# Altium schematic sheet Communication.SchDoc(Designator)
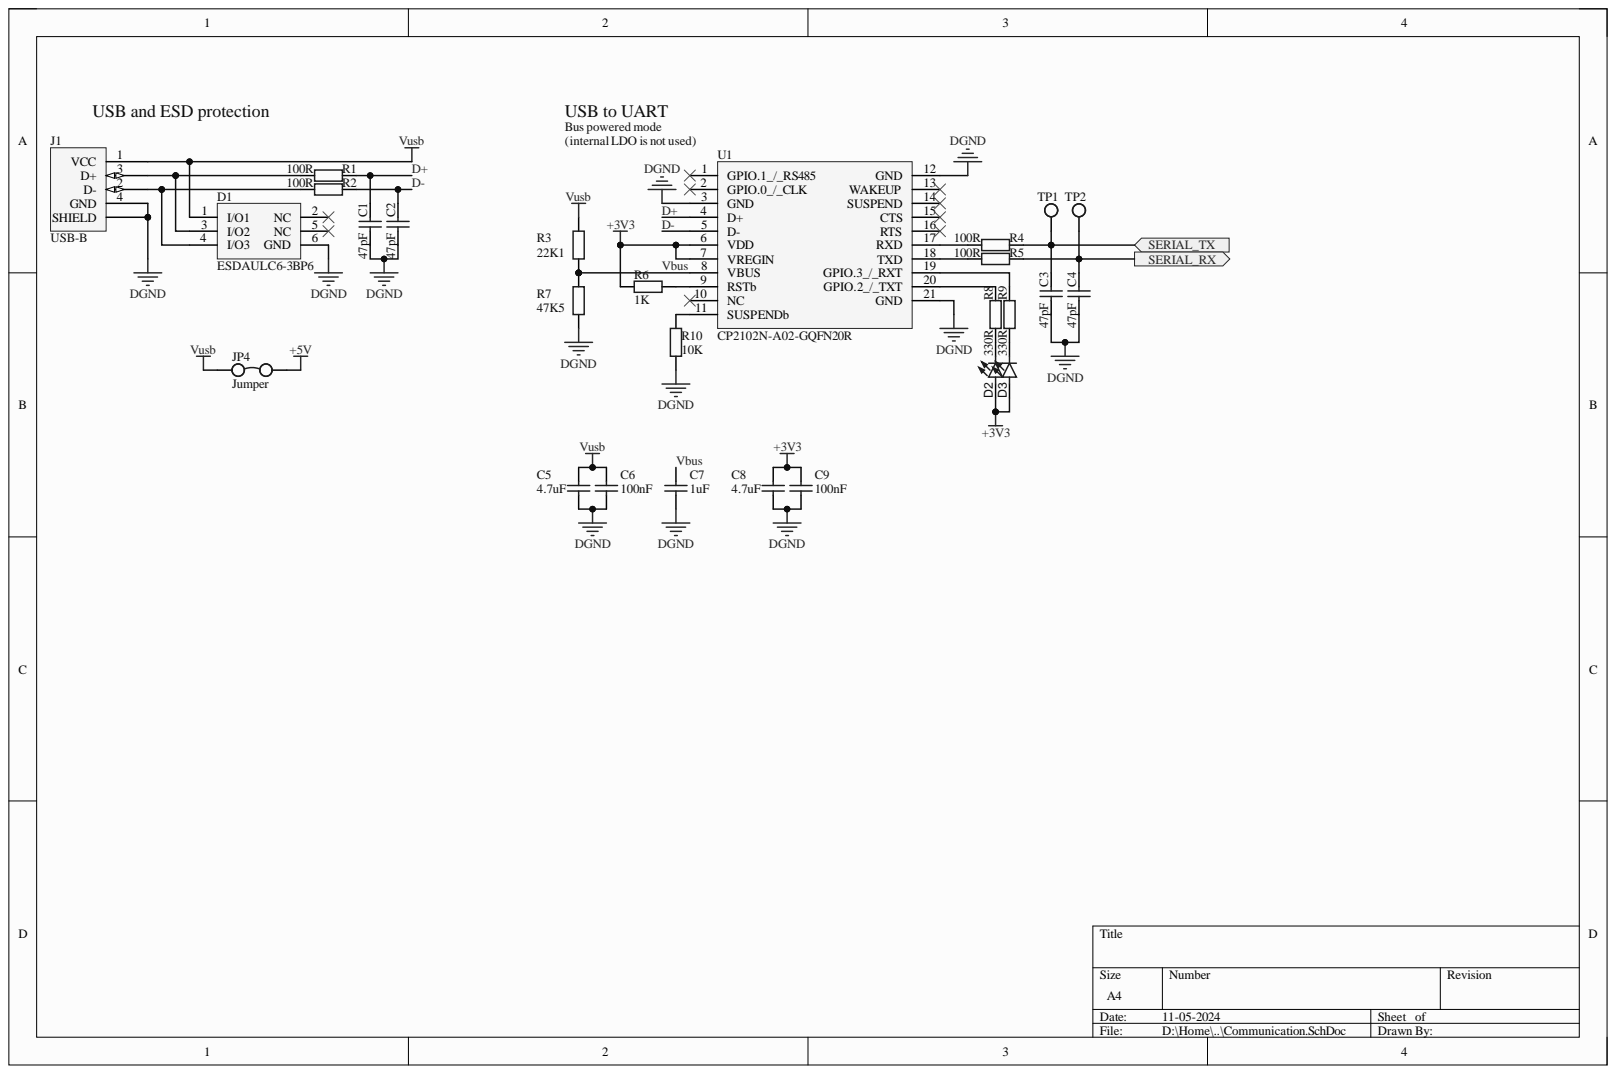
[diagram p0: Communication.SchDoc]

## Parts
C1  47pF  [CAP0603]
C2  47pF  [CAP0603]
C3  47pF  [CAP0603]
C4  47pF  [CAP0603]
C5  4.7uF  [CAP0603]
C6  100nF  [CAP0603]
C7  1uF  [CAP0603]
C8  4.7uF  [CAP0603]
C9  100nF  [CAP0603]
D1  ESDAULC6-3BP6  [SOT-666]
D2  Value  [LED0603]  (value not drawn)
D3  Value  [LED0603]  (value not drawn)
J1  USB-B  [USB-B-FEMALE]
JP4  Jumper  [PIN-1X2]
R1  100R  [RES0603]
R2  100R  [RES0603]
R3  22K1  [RES0603]
R4  100R  [RES0603]
R5  100R  [RES0603]
R6  1K  [RES0603]
R7  47K5  [RES0603]
R8  330R  [RES0603]
R9  330R  [RES0603]
R10  10K  [RES0603]
TP1  Test Point  [TP-1.5HOLE]  (value not drawn)
TP2  Test Point  [TP-1.5HOLE]  (value not drawn)
U1  CP2102N-A02-GQFN20R  [QFN-20]

## Ports
SERIAL_RX, SERIAL_TX

## Nets
+3V3: C8.2 C9.1 D2.2 D3.2 R6.1 U1.6(VDD) U1.7(VREGIN)
+5V: JP4.2
DGND: C1.1 C2.1 C3.1 C4.1 C5.1 C6.2 C7.1 C8.1 C9.2 D1.6(GND) J1.0(SHIELD) J1.4(GND) R7.1 R10.1 U1.3(GND) U1.12(GND) U1.21(GND)
Vusb: C5.2 C6.1 D1.1(I/O1) J1.1(VCC) JP4.1 R3.2
D+: C1.2 R1.2 U1.4(D+)
D-: C2.2 R2.2 U1.5(D-)
SERIAL_RX: C4.2 R5.2 TP2.1 ; port SERIAL_RX
SERIAL_TX: C3.2 R4.2 TP1.1 ; port SERIAL_TX
Vbus: C7.2 R3.1 R7.2 U1.8(VBUS)
NetD1_2: D1.2(NC)
NetD1_3: D1.3(I/O2) J1.3(D+) R1.1
NetD1_4: D1.4(I/O3) J1.2(D-) R2.1
NetD1_5: D1.5(NC)
NetD2_1: D2.1 R8.2
NetD3_1: D3.1 R9.2
NetR4_1: R4.1 U1.17(RXD)
NetR5_1: R5.1 U1.18(TXD)
NetR6_2: R6.2 U1.9(RSTb)
NetR8_1: R8.1 U1.20(GPIO.2_/_TXT)
NetR9_1: R9.1 U1.19(GPIO.3_/_RXT)
NetR10_2: R10.2 U1.11(SUSPENDb)
NetU1_1: U1.1(GPIO.1_/_RS485)
NetU1_2: U1.2(GPIO.0_/_CLK)
NetU1_10: U1.10(NC)
NetU1_13: U1.13(WAKEUP)
NetU1_14: U1.14(SUSPEND)
NetU1_15: U1.15(CTS)
NetU1_16: U1.16(RTS)

## Library data of the parts
C1-C4 = 47pF: Ceramic Capacitor, Multilayer, Ceramic, 100V, 5% +Tol, 5% -Tol, C0G, 30ppm/Cel TC, 0.000047uF, Surface Mount, 0603; Manufacturer: KEMET; Manufacturer Part Number: C0603C470J1GACTU; Multilayer: Yes; Capacitor Type: Ceramic; Case/Package: 0603; Category: Ceramic Capacitors; Component Kind: Standard; ComponentLink1Description: https://datasheet.ciiva.com:443/pdfs/VipMasterIC/IC/KEME/KEME-S-A0005605168/KEME-S-A0005605168-1.pdf?src-supplier=IHS+Markit; ComponentLink1URL: https://datasheet.ciiva.com:443/pdfs/VipMasterIC/IC/KEME/KEME-S-A0005605168/KEME-S-A0005605168-1.pdf?src-supplier=IHS+Markit; ComponentLink2Description: https://datasheet.ciiva.com/28668/1789732-28668693.pdf?src-supplier=Element14; ComponentLink2URL: https://datasheet.ciiva.com/28668/1789732-28668693.pdf?src-supplier=Element14; ComponentLink3Description: https://datasheet.ciiva.com/18193/getdatasheetpartid-544691-18193046.pdf?src-supplier=Verical; ComponentLink3URL: https://datasheet.ciiva.com/18193/getdatasheetpartid-544691-18193046.pdf?src-supplier=Verical; ComponentLink4Description: https://datasheet.ciiva.com/18101/getdatasheetpartid-38001-18101098.pdf?src-supplier=Verical; ComponentLink4URL: https://datasheet.ciiva.com/18101/getdatasheetpartid-38001-18101098.pdf?src-supplier=Verical; Dielectric Material: Ceramic; Height: 0.8mm; JESD-609 Code: e3; Length: 1.6mm; Max Operating Temperature: 125°C; Min Operating Temperature: -55°C; Mounting Technology: Surface Mount; Package Shape: Rectangular; Package Style: SMTMeter; Packaging: Tape and Reel; Pins: 2; Pricing: 1=0.1, 10=0.026, 50=0.026, 100=0.016, 1000=0.012, 10000=0.007 (USD); Rated DC Voltage (URdc): 100V; Reach SVHC Compliant: Yes; RoHS: Yes; RoHS Compliant: Yes; Stock: 43381; Supplier: Mouser; Supplier Part Number: 80-C0603C470J1G; Temperature Characteristics Code: C0G; Terminal Finish: Nickel; Termination: Wraparound; Tolerance  (fill in as +-): 5%; Tolerance (fill in as +-): 5%; Value: 47pF; Width: 0.8mm; Library Name: UPS_Schlib.SchLib
C5 C8 = 4.7uF: Capacitor; Component Kind: Standard; Value: 4.7uF; Library Name: UPS_Schlib.SchLib
C6 C9 = 100nF: Capacitor; Component Kind: Standard; Value: 100nF; Library Name: UPS_Schlib.SchLib
C7 = 1uF: Capacitor; Component Kind: Standard; Value: 1uF; Library Name: UPS_Schlib.SchLib
D1 = ESDAULC6-3BP6: TVS Diode (Bi-directional); Manufacturer_Name: STMicroelectronics; Arrow Part Number: ESDAULC6-3BP6; Arrow Price/Stock: https://www.arrow.com/en/products/esdaulc6-3bp6/stmicroelectronics?region=europe; Component Kind: Standard; Datasheet Link: https://www.st.com/resource/en/datasheet/esdaulc6-3bp6.pdf; Height: 0.6mm; Manufacturer_Part_Number: ESDAULC6-3BP6; Mouser Part Number: 511-ESDAULC63BP6; Mouser Price/Stock: https://www.mouser.co.uk/ProductDetail/STMicroelectronics/ESDAULC6-3BP6?qs=eU2TTeT5rM3P6hIT2KJeMw%3D%3D; Library Name: UPS_Schlib.SchLib
D2 D3 = LED Green: Single Color LED, Bright Green, Water Clear, 1.1mm; Manufacturer: Wurth Electronics; Manufacturer Part Number: 150060VS75000; Channels: 1; Forward Current: 30mA; Luminous Intensity-Nom: 40mcd; Overall Height: 0.7mm; Shape: Rectangular; Viewing Angle: 140°; Category: LEDs; Color: Green; Component Kind: Standard; ComponentLink1Description: https://datasheet.ciiva.com:443/pdfs/VipMasterIC/IC/MIDC/MIDC-S-A0007768636/MIDC-S-A0007768636-1.pdf?src-supplier=IHS+Markit; ComponentLink1URL: https://datasheet.ciiva.com:443/pdfs/VipMasterIC/IC/MIDC/MIDC-S-A0007768636/MIDC-S-A0007768636-1.pdf?src-supplier=IHS+Markit; ComponentLink2Description: https://datasheet.ciiva.com/29562/150060vs75000-29562919.pdf?src-supplier=Digikey; ComponentLink2URL: https://datasheet.ciiva.com/29562/150060vs75000-29562919.pdf?src-supplier=Digikey; Lens Style: WATER CLEAR; Max Operating Temperature: 85°C; Min Operating Temperature: -40°C; Mounting Technology: Surface Mount; Optoelectronic Device Type: SINGLE COLOR LED; Packaging: Tape and Reel; Pins: 2; Pricing: 1=0.15, 10=0.15, 50=0.142, 100=0.122, 1000=0.116, 10000=0.116 (USD); Reach SVHC Compliant: Yes; RoHS: Yes; RoHS Compliant: Yes; Size: 1.1mm; Stock: 96633; Supplier: Mouser; Supplier Part Number: 710-150060VS75000; Terminal Pitch: 1.6mm; Library Name: UPS_Schlib.SchLib
J1 = USB-B: USB Connector; Component Kind: Standard; Library Name: UPS_Schlib.SchLib
JP4 = Jumper: 2 pin jumper connection; Component Kind: Standard; Library Name: UPS_Schlib.SchLib
R1 R2 R4 R5 = 100R: Resistor; Component Kind: Standard; Value: 100R; Library Name: UPS_Schlib.SchLib
R3 = 22K1: Resistor; Component Kind: Standard; Value: 22K1; Library Name: UPS_Schlib.SchLib
R6 = 1K: Resistor; Component Kind: Standard; Value: 1K; Library Name: UPS_Schlib.SchLib
R7 = 47K5: Resistor; Component Kind: Standard; Value: 47K5; Library Name: UPS_Schlib.SchLib
R8 R9 = 330R: Resistor; Component Kind: Standard; Value: 330R; Library Name: UPS_Schlib.SchLib
R10 = 10K: Resistor; Component Kind: Standard; Value: 10K; Library Name: UPS_Schlib.SchLib
U1 = CP2102N-A02-GQFN20R: USB-UART Bridge; Manufacturer_Name: Silicon Labs; Arrow Part Number: CP2102N-A02-GQFN20R; Arrow Price/Stock: https://www.arrow.com/en/products/cp2102n-a02-gqfn20r/silicon-labs?utm_currency=USD&region=nac; Component Kind: Standard; Datasheet Link: https://www.silabs.com/documents/public/data-sheets/cp2102n-datasheet.pdf; Height: 0.8mm; Manufacturer_Part_Number: CP2102N-A02-GQFN20R; Mouser Part Number: 634-CP2102NA02QFN20R; Mouser Price/Stock: https://www.mouser.co.uk/ProductDetail/Silicon-Labs/CP2102N-A02-GQFN20R?qs=u16ybLDytRbf2uwPkMBdUg%3D%3D; Library Name: UPS_Schlib.SchLib
TP1 TP2 = Test Point: Component Kind: Standard; Description: Test Point; Library Name: UPS_Schlib.SchLib
